# Altium schematic sheet BG FastNet CAN.SchDoc
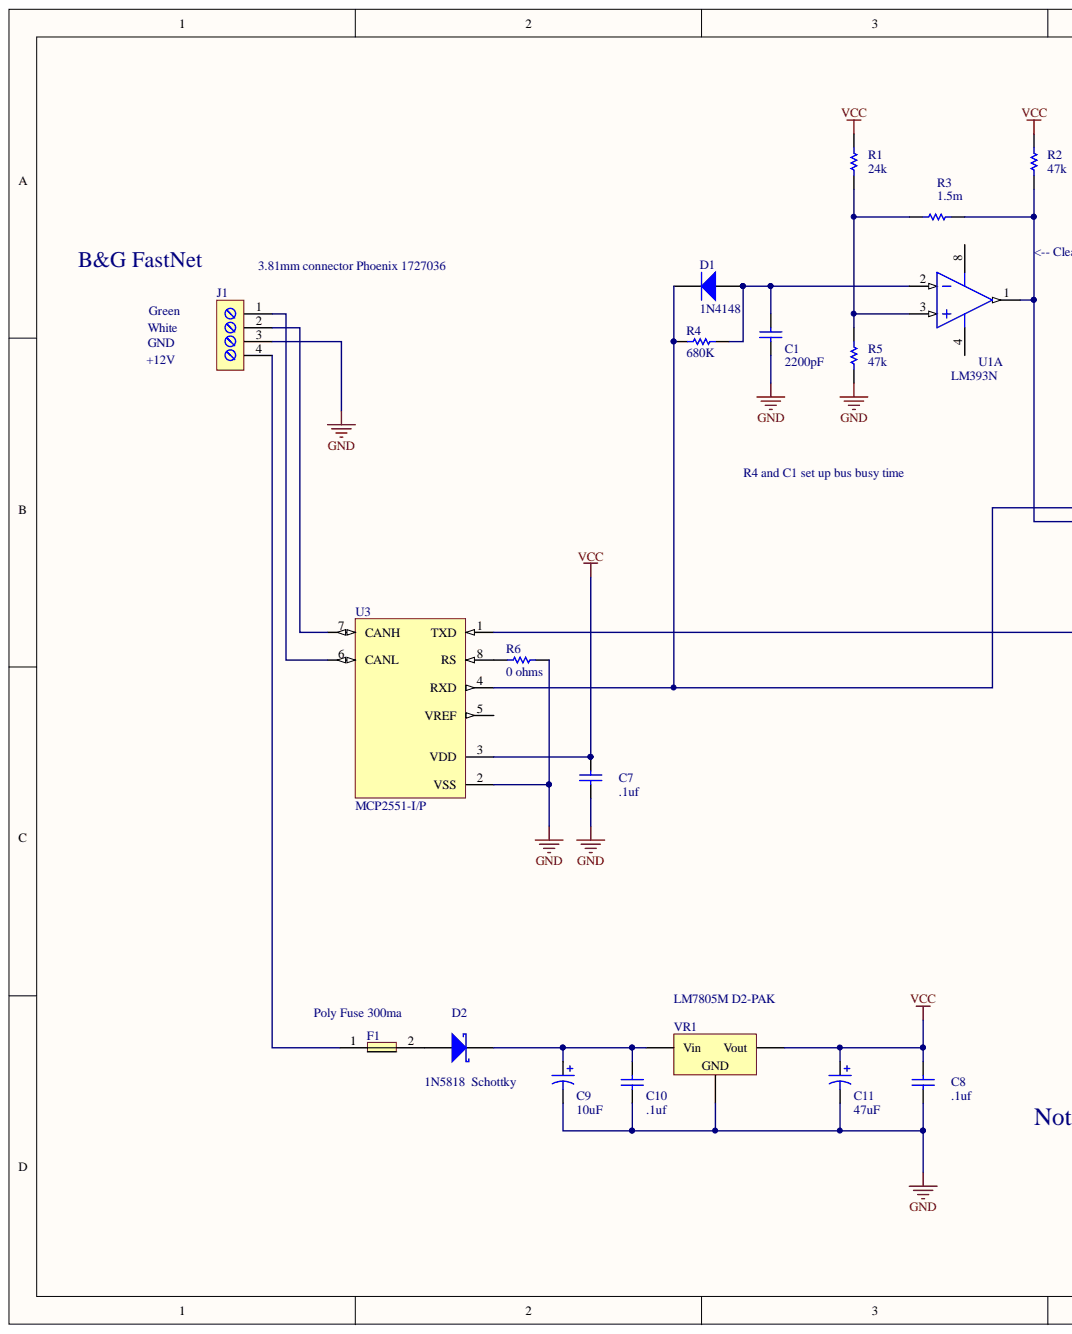
[diagram p0: BG FastNet CAN.SchDoc, left]
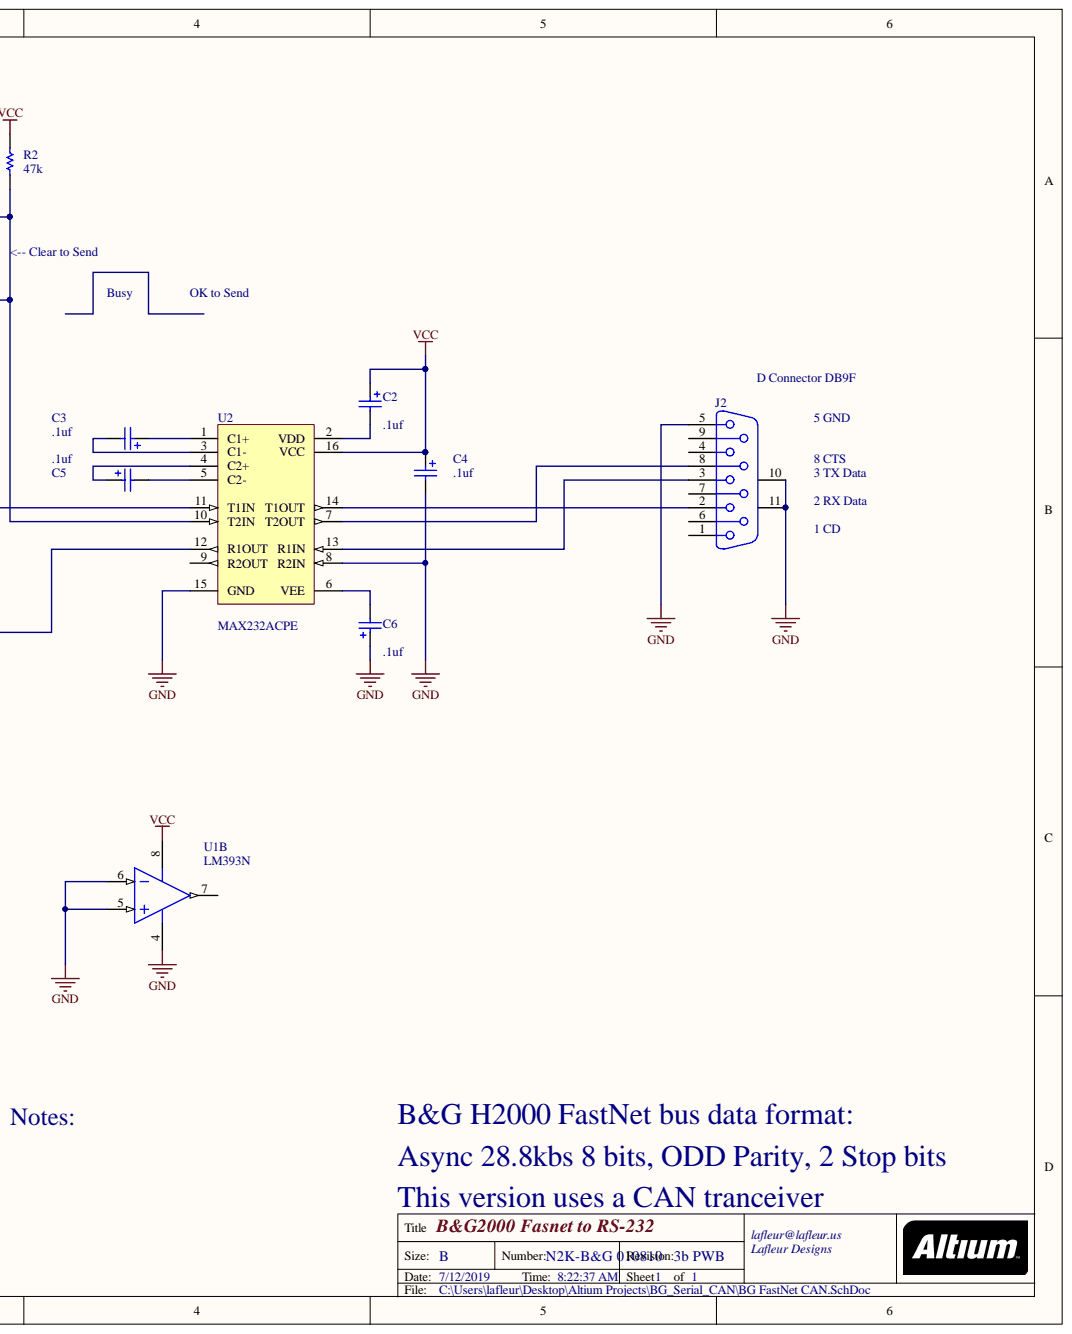
[diagram p1: BG FastNet CAN.SchDoc, right]

## Parts
C1  value 2200pF  [RAD-0.2]
C2  value .1uf  [RAD-0.2]
C3  value .1uf  [RAD-0.2]
C4  value .1uf  [RAD-0.2]
C5  value .1uf  [RAD-0.2]
C6  value .1uf  [RAD-0.2]
C7  value .1uf  [RAD-0.2]
C8  value .1uf  [RAD-0.2]
C9  value 10uF  [CAPPR2-5x6.8]
C10  value .1uf  [RAD-0.2]
C11  value 47uF  [CAPPR2-5x6.8]
D1  1N4148  [DO-35]
D2  1N5818  Schottky  [AXIAL-0.4]
F1  Poly Fuse 300ma  [RAD-0.2]
J1  (no value)  [TS3.81X4]
J2  D Connector DB9F  [DSUB1.385-2H9]
R1  24k  [AXIAL-0.4]
R2  47k  [AXIAL-0.4]
R3  1.5m  [AXIAL-0.4]
R4  680K  [AXIAL-0.4]
R5  47k  [AXIAL-0.4]
R6  0 ohms  [AXIAL-0.4]
U1  LM393N  [DIP-8]  (parts A,B)
U2  MAX232ACPE  [PE16A]
U3  MCP2551-I/P  [PDIP300-P8]
VR1  LM7805M D2-PAK  [TO254P1510-3_4N]

## Nets
GND: C1.1 C4.2 C6.1 C7.1 C8.1 C9.2 C10.1 C11.2 J1.3 J2.5 J2.10 J2.11 R5.2 R6.2 U1.4 U1.5 U1.6 U2.8(R2IN) U2.15(GND) U3.2(VSS) VR1.2
VCC: C2.1 C4.1 C7.2 C8.2 C11.1 R1.2 R2.1 U1.8 U2.16(VCC) U3.3(VDD) VR1.3
NetC1_2: C1.2 D1.1 R4.2 U1.2
NetC2_2: C2.2 U2.2(VDD)
NetC3_1: C3.1 U2.1(C1+)
NetC3_2: C3.2 U2.3(C1-)
NetC5_1: C5.1 U2.4(C2+)
NetC5_2: C5.2 U2.5(C2-)
NetC6_2: C6.2 U2.6(VEE)
NetC9_1: C9.1 C10.2 D2.2 VR1.1
NetD1_2: D1.2 R4.1 U2.11(T1IN) U3.4(RXD)
NetD2_1: D2.1 F1.2
NetF1_1: F1.1 J1.4
NetJ1_1: J1.1 U3.6(CANL)
NetJ1_2: J1.2 U3.7(CANH)
NetJ2_2: J2.2 U2.14(T1OUT)
NetJ2_3: J2.3 U2.13(R1IN)
NetJ2_8: J2.8 U2.7(T2OUT)
NetR1_1: R1.1 R3.2 R5.1 U1.3
NetR2_2: R2.2 R3.1 U1.1 U2.10(T2IN)
NetR6_1: R6.1 U3.8(RS)
NetU2_12: U2.12(R1OUT) U3.1(TXD)
N00002:

Not connected: J2 1 4 6 7 9; U1 7; U2 9(R2OUT); U3 5(VREF)

## Library data of the parts
C1 C7 C8 C10 = Cap: Capacitor; Component Kind: Standard; LatestRevisionDate: 17-Jul-2002; LatestRevisionNote: Re-released for DXP Platform.; Library Name: Miscellaneous Devices.IntLib; PackageReference: RAD-0.3; Published: 8-Jun-2000; Simulation: CAP; Publisher: Altium Limited
C2-C6 = Cap Pol2: Polarized Capacitor (Axial); Component Kind: Standard; LatestRevisionDate: 17-Jul-2002; LatestRevisionNote: Re-released for DXP Platform.; Library Name: Miscellaneous Devices.IntLib; PackageReference: POLAR0.8; Published: 8-Jun-2000; Simulation: CAP; Publisher: Altium Limited
C9 C11 = Cap Pol1: Polarized Capacitor (Radial); Component Kind: Standard; LatestRevisionDate: 17-Jul-2002; LatestRevisionNote: Re-released for DXP Platform.; Library Name: Miscellaneous Devices.IntLib; PackageReference: RB7.6-15; Published: 8-Jun-2000; Simulation: CAP; Publisher: Altium Limited
D1 = 1N4148: High Conductance Fast Diode; Code_JEDEC: DO-204-AH; Component Kind: Standard; ComponentLink1Description: Manufacturer Link; ComponentLink1URL: http://www.fairchildsemi.com/; ComponentLink2Description: Datasheet; ComponentLink2URL: http://www.fairchildsemi.com/ds/1N%2F1N4148.pdf; DatasheetDocument: 1997; LatestRevisionDate: 17-Jul-2002; LatestRevisionNote: Re-released for DXP Platform.; Library Name: FSC Discrete Diode.IntLib; PackageReference: DO-35; Published: 8-Jun-2000; Simulation: 1N4148; Publisher: Altium Limited
D2 = D Schottky: Schottky Diode; Code_JEDEC: DO-214-AA; Component Kind: Standard; LatestRevisionDate: 17-Jul-2002; LatestRevisionNote: Re-released for DXP Platform.; Library Name: Miscellaneous Devices.IntLib; PackageReference: SMB; Published: 8-Jun-2000; Simulation: SKYDIODE; Publisher: Altium Limited
F1 = Poly Fuse: Component Kind: Standard; Description: Poly Fuse; Library Name: N2K Sensor Board_3.SCHLIB
J1 = TS4A: Screw Terminal 3.81mm 4X; Component Kind: Standard; Library Name: N2K Sensor Board_3.SCHLIB
J2 = D Connector 9: 7906 Series DSUB Right Angle Socket Assembly, 9 Positions; Component Kind: Standard; Published: 5-Jan-2004; Library Name: Altium Nanoboard Project.IntLib; Publisher: Altium Limited
R1-R6 = ERJ8EN: Precision Thick Film Chip Resistor, 10 Ohm to 2.2M Ohm Range, 1% Tolerance, 1206 Size, 0.125 W; Code_IPC: 3216 [1206]; Component Kind: Standard; ComponentLink1Description: Manufacturer Link; ComponentLink1URL: http://www.panasonic.com/industrial/components/resistive_products.htm; ComponentLink2Description: Datasheet; ComponentLink2URL: http://www.panasonic.com/industrial/components/pdf/AOA0000CE2.pdf; LatestRevisionDate: 19-Mar-2004; LatestRevisionNote: Silkscreen size reduced.; Library Name: Panasonic Resistor.IntLib; PackageDocument: Mar-2003; PackageReference: 8-1206; Published: 17-Dec-2003; Simulation: RESISTOR; Publisher: Altium Limited
U1 = LM393MX: Low Power Low Offset Voltage Dual Comparator; Manufacturer: National Semiconductor; PackageTopMarking: NSZXTT*LM*393M; Code_IPC: SOIC127P600-8; Code_JEDEC: MS-012-AA; Component Kind: Standard; ComponentLink1Description: LM393 Datasheet; ComponentLink1URL: http://www.national.com/ds/LM/LM393.pdf; ComponentLink2Description: LM393  Web Page; ComponentLink2URL: http://www.national.com/pf/LM/LM393.html; ComponentLink3Description: M08A Package Page; ComponentLink3URL: http://www.national.com/packaging/folders/m08a.html; CompType: Comparator; DatasheetVersion: Aug-2002; DigiKeyPartNumber: LM393MXCT-ND; Library Name: NSC Comparator.IntLib; PackageDescription: SOIC Narrow, 4.9 x 3.9 x 1.45mm, 8 Lead, 1.27mm Pitch; PackageReference: M08A; PackageVersion: revL, Sep-2006; PartNumber: LM393MX; PinCount: 8; Published: 4-Dec-2008; RoHS: O; Publisher: Altium Limited
U2 = MAX232ACPE: +5V Powered, Multi-Channel RS-232 Driver/Receiver; Code_JEDEC: MS-001-BB; Component Kind: Standard; ComponentLink1Description: Manufacturer Link; ComponentLink1URL: http://www.maxim-ic.com/; ComponentLink2Description: Datasheet; ComponentLink2URL: http://pdfserv.maxim-ic.com/en/ds/MAX220-MAX249.pdf; LatestRevisionDate: 29-May-2009; LatestRevisionNote: Component Body Added.; Library Name: Maxim Communication Transceiver.IntLib; PackageDescription: DIP, 16-Pin, Row Spacing 7.62 mm, Pitch 2.54 mm; PackageReference: PE16A; PackageVersion: 1997; PCB3D: PE16; Published: 16-Jan-2001; Publisher: Altium Limited
U3 = MCP2551-I/P: High-Speed CAN Transceiver, 8-Pin PDIP, Industrial Temperature; Code_JEDEC: MS-001; Component Kind: Standard; ComponentLink1Description: Manufacturer Link; ComponentLink1URL: http://www.microchip.com; ComponentLink2Description: Datasheet; ComponentLink2URL: http://ww1.microchip.com/downloads/en/DeviceDoc/21667E.pdf; ComponentLink3Description: Packaging Specification; ComponentLink3URL: http://ww1.microchip.com/downloads/en/PackagingSpec/00049AW.pdf; DatasheetVersion: revE, Jan-2007; Library Name: Microchip Interface.IntLib; PackageDescription: 8-Lead Plastic Dual In-Line (P) - 300 mil Body [PDIP]; PackageReference: PDIP300-P8; PackageVersion: revAW, Oct-2008; Published: 29-Jan-2009; Publisher: Altium Limited
VR1 = Volt Reg: Voltage Regulator; Component Kind: Standard; LatestRevisionDate: 29-May-2009; LatestRevisionNote: IPC-7351 Footprint Added.; Library Name: Miscellaneous Devices.IntLib; PackageDescription: 3-Pin Transistor Outline Package 2.54 mm Pitch, 15.1 mm Lead Span; PackageReference: D2PAK; Published: 24-Mar-1999; Publisher: Altium Limited
